# Altium schematic sheet atmega32u4.SchDoc(atmega32u4)
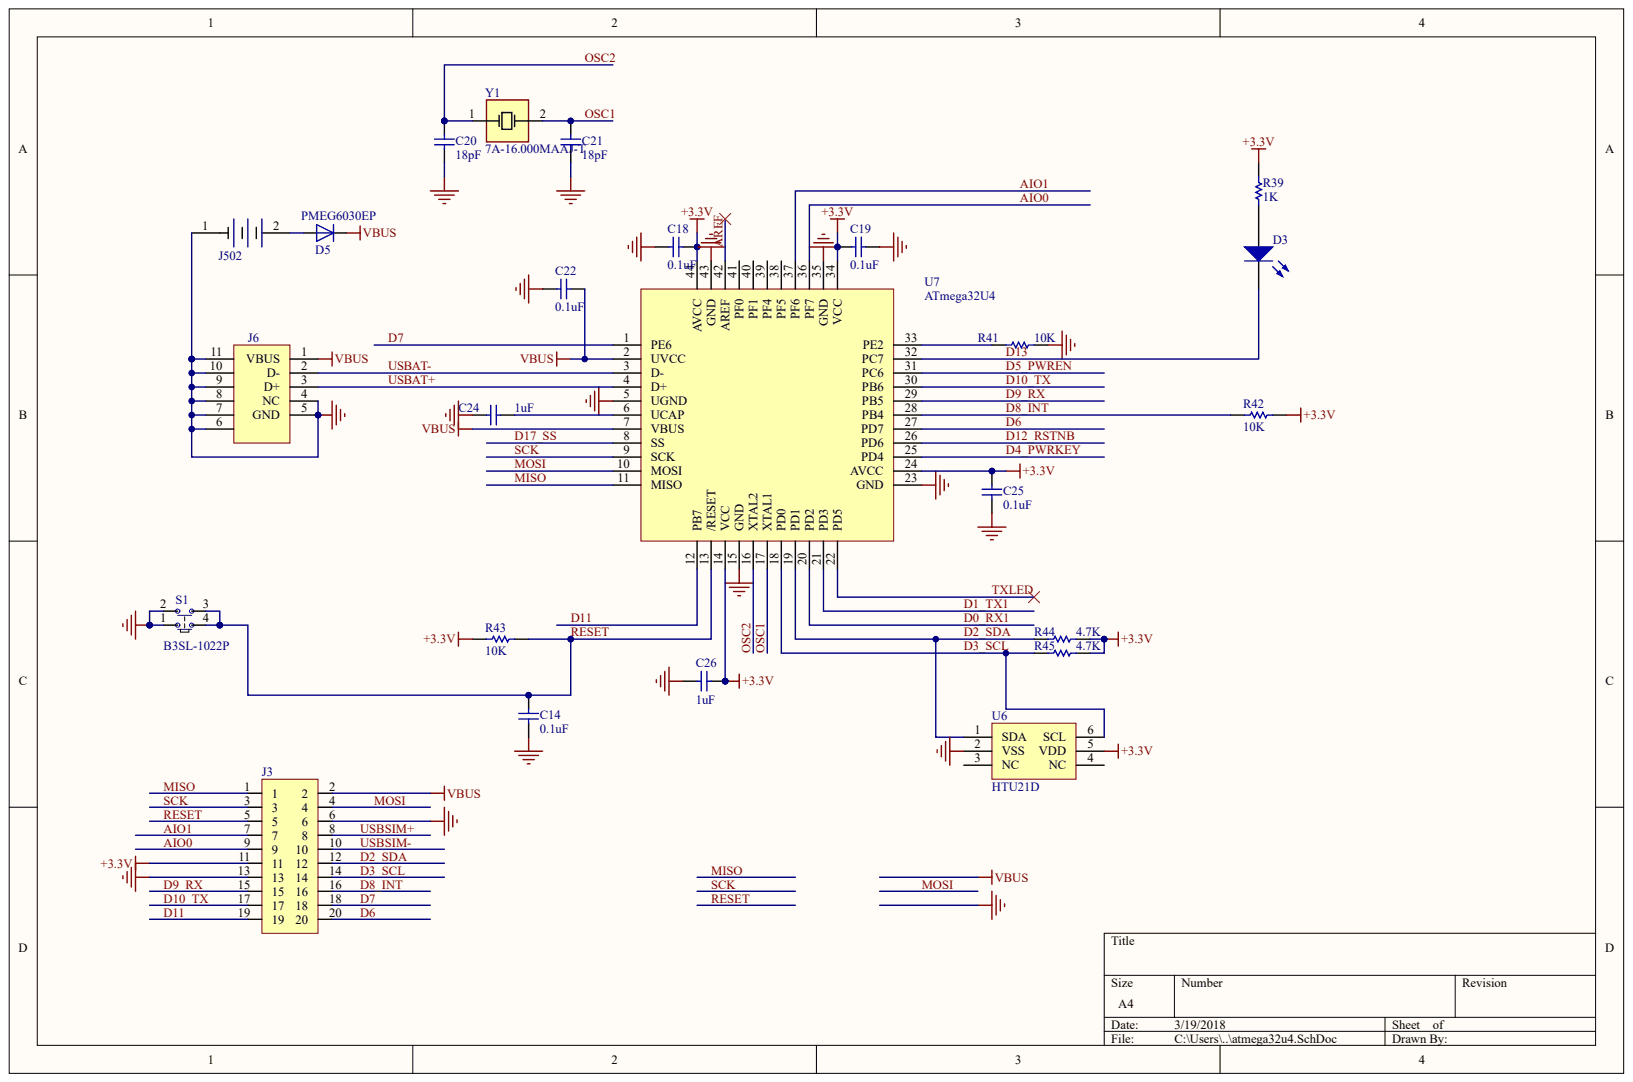
[diagram p0: atmega32u4.SchDoc]

## Parts
C14  0.1uF
C18  0.1uF
C19  0.1uF
C20  18pF
C21  18pF
C22  0.1uF
C24  1uF
C25  0.1uF
C26  1uF
D3  LG Q971-KN-1  (value not drawn)
D5  PMEG6030EP
J3  (no value)
J6  10118193-0001LF  (value not drawn)
J502  KEYSTONE 2479  (value not drawn)
R39  1K
R41  10K
R42  10K
R43  10K
R44  4.7K
R45  4.7K
S1  B3SL-1022P
U6  HTU21D
U7  ATmega32U4
Y1  7A-16.000MAAJ-T

## Nets
+3.3V: C18.2 C19.2 C25.2 C26.2 J3.11 R39.1 R42.2 R43.1 R44.2 R45.2 U6.5(VDD) U7.14(VCC) U7.24(AVCC) U7.34(VCC) U7.44(AVCC)
GND: C14.1 C18.1 C19.1 C20.1 C21.1 C22.1 C24.2 C25.1 C26.1 J3.6 J3.13 J6.4(NC) J6.5(GND) J6.6 J6.7 J6.8 J6.9 J6.10 J6.11 J502.1 R41.1 S1.1 S1.2 U6.2(VSS) U7.5(UGND) U7.15(GND) U7.23(GND) U7.35(GND) U7.43(GND)
VBUS: C22.2 D5.K J3.2 J6.1(VBUS) U7.2(UVCC) U7.7(VBUS)
AIO0: J3.9 U7.36(PF7)
AIO1: J3.7 U7.37(PF6)
AREF: U7.42(AREF)
D0_RX1: U7.20(PD2)
D1_TX1: U7.21(PD3)
D2_SDA: J3.12 R44.1 U6.1(SDA) U7.19(PD1)
D3_SCL: J3.14 R45.1 U6.6(SCL) U7.18(PD0)
D4_PWRKEY: U7.25(PD4)
D5_PWREN: U7.31(PC6)
D6: J3.20 U7.27(PD7)
D7: J3.18 U7.1(PE6)
D8_INT: J3.16 R42.1 U7.28(PB4)
D9_RX: J3.15 U7.29(PB5)
D10_TX: J3.17 U7.30(PB6)
D11: J3.19 U7.12(PB7)
D12_RSTNB: U7.26(PD6)
D13: D3.2 U7.32(PC7)
D17_SS: U7.8(SS)
MISO: J3.1 U7.11(MISO)
MOSI: J3.4 U7.10(MOSI)
OSC1: C21.2 U7.17(XTAL1) Y1.2
OSC2: C20.2 U7.16(XTAL2) Y1.1
RESET: C14.2 J3.5 R43.2 S1.3 S1.4 U7.13(/RESET)
SCK: J3.3 U7.9(SCK)
TXLED: U7.22(PD5)
USBAT+: J6.3(D+) U7.4(D+)
USBAT-: J6.2(D-) U7.3(D-)
USBSIM+: J3.8
USBSIM-: J3.10
NetC24_1: C24.1 U7.6(UCAP)
NetD3_1: D3.1 R39.2
NetD5_A: D5.A J502.2
NetR41_2: R41.2 U7.33(PE2)

Not connected: U6 3(NC) 4(NC); U7 38(PF5) 39(PF4) 40(PF1) 41(PF0)

## Library data of the parts
C14 C18-C22 C24-C26 = (no symbol name): Tolerance: 10%; Voltage Rating: 50V
  C14 C18 C19 C22 C25: Value: 0.1uF
  C20 C21: Value: 18pF
  C24 C26: Value: 1uF
D3 = (no symbol name): Manufacturer: OSRAM; PartNumber: LG Q971-KN-1
D5 = (no symbol name): Manufacturer: NXP; PartNumber: PMEG6030EP
J6 = (no symbol name): Manufacturer: FCI; PartNumber: 10118193-0001LF
R39 R41-R45 = (no symbol name): Tolerance: 1%
  R39: Value: 1K
  R41-R43: Value: 10K
  R44 R45: Value: 4.7K
S1 = (no symbol name): Manufacturer: OMRON; PartNumber: B3SL-1022P
U6 = (no symbol name): Manufacturer: TE; PartNumber: HTU21D
U7 = (no symbol name): Manufacturer: ATMEL; PartNumber: ATmega32U4
Y1 = (no symbol name): Manufacturer: TXC; PartNumber: 7A-16.000MAAJ-T
J3 J502 = (no symbol name)
  J502: Manufacturer: KEYSTONE; PartNumber: KEYSTONE 2479
